# Altium schematic sheet Power_Selection.SchDoc
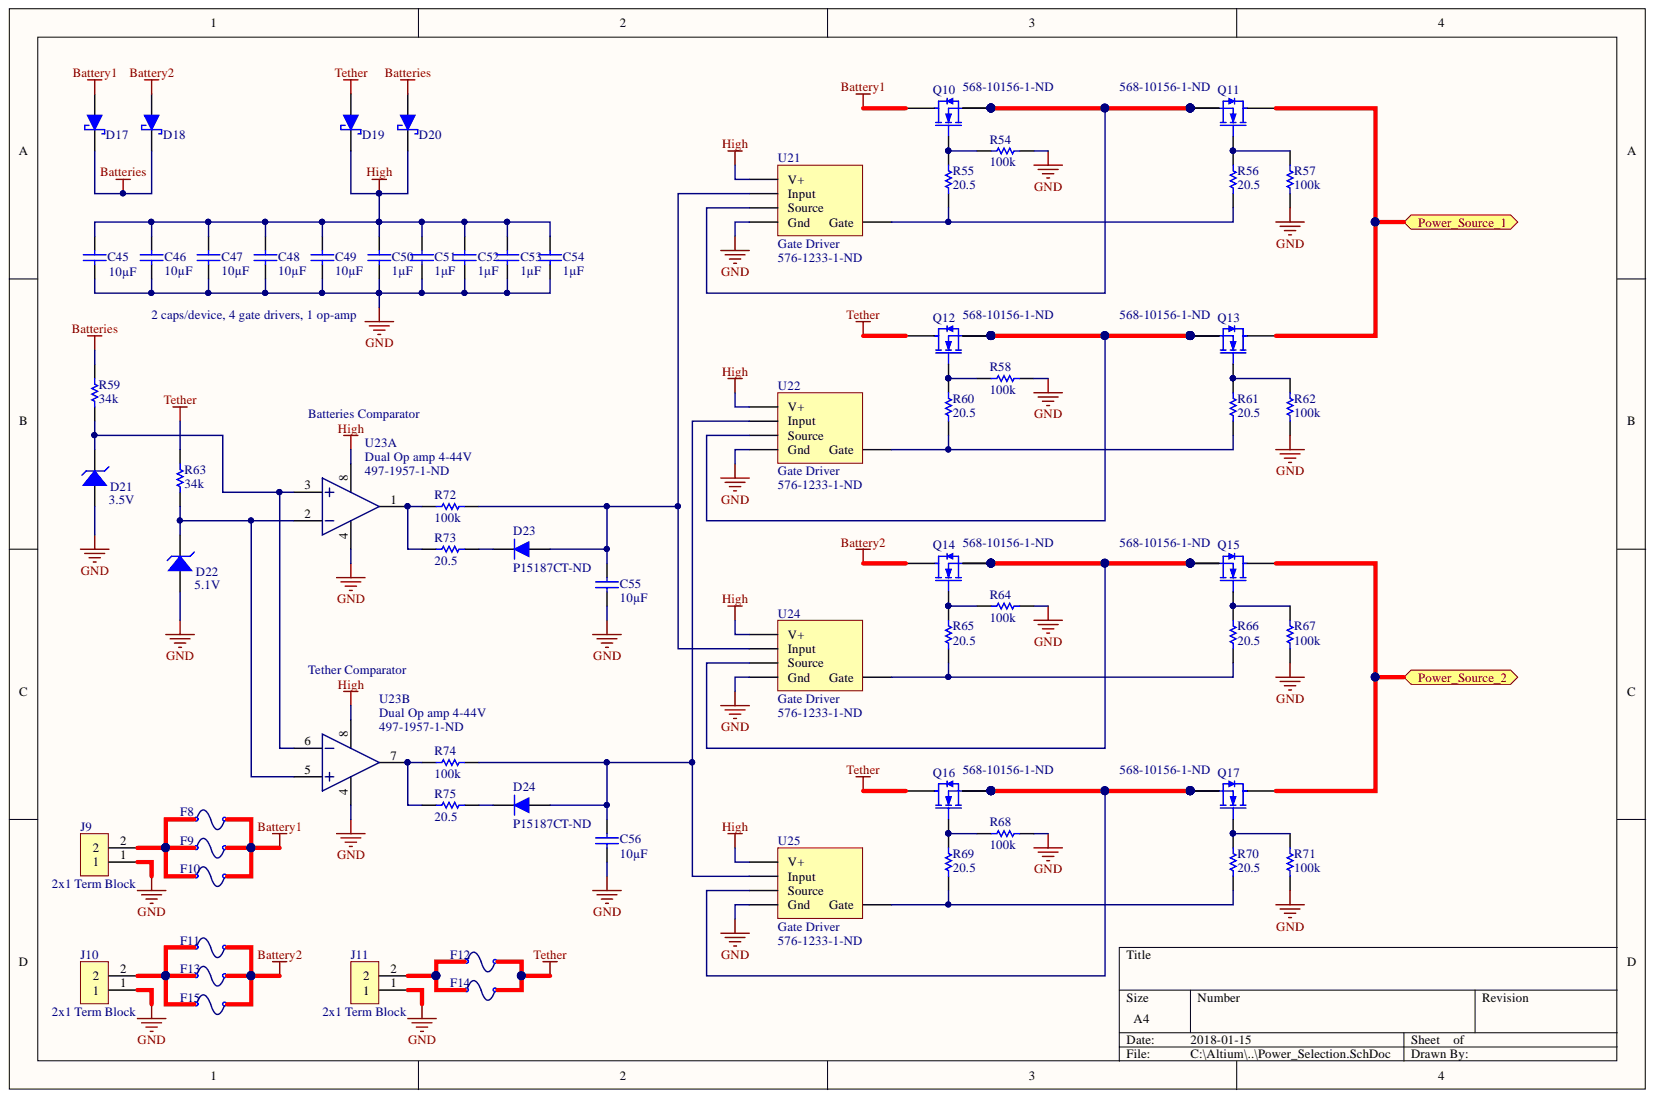
[diagram p0: Power_Selection.SchDoc]

## Parts
C45  10µF  [CAPC3216N(1206")]
C46  10µF  [CAPC3216N(1206")]
C47  10µF  [CAPC3216N(1206")]
C48  10µF  [CAPC3216N(1206")]
C49  10µF  [CAPC3216N(1206")]
C50  1μF  [CAPC1608N(0603")]
C51  1μF  [CAPC1608N(0603")]
C52  1μF  [CAPC1608N(0603")]
C53  1μF  [CAPC1608N(0603")]
C54  1μF  [CAPC1608N(0603")]
C55  10µF  [CAPC3216N(1206")]
C56  10µF  [CAPC3216N(1206")]
D17  CUS08F30H3FCT-ND  [SC-76]  (value not drawn)
D18  CUS08F30H3FCT-ND  [SC-76]  (value not drawn)
D19  CUS08F30H3FCT-ND  [SC-76]  (value not drawn)
D20  CUS08F30H3FCT-ND  [SC-76]  (value not drawn)
D21  Voltage - Breakdown (Min): 3.5V  [SODFL160X70-2N]  (hidden comment: UCLAMP3301H.TCT)
D22  Voltage - Zener (Nom) (Vz): 5.1V  (hidden comment: MM3Z5V1ST1G)
D23  Supplier Part Number 1: P15187CT-ND  [SODFL535X110-2N]  (hidden comment: DA2S10400L, SOD323F / SC-90)  (no BOM)
D24  Supplier Part Number 1: P15187CT-ND  [SODFL535X110-2N]  (hidden comment: DA2S10400L, SOD323F / SC-90)  (no BOM)
F8  MINI  [MINI BLADE FUSEHOLDER]  (value not drawn)
F9  MINI  [MINI BLADE FUSEHOLDER]  (value not drawn)
F10  MINI  [MINI BLADE FUSEHOLDER]  (value not drawn)
F11  MINI  [MINI BLADE FUSEHOLDER]  (value not drawn)
F12  MINI  [MINI BLADE FUSEHOLDER]  (value not drawn)
F13  MINI  [MINI BLADE FUSEHOLDER]  (value not drawn)
F14  MINI  [MINI BLADE FUSEHOLDER]  (value not drawn)
F15  MINI  [MINI BLADE FUSEHOLDER]  (value not drawn)
J9  2x1 Term Block  [2x1 Terminal Blocks EM2929]
J10  2x1 Term Block  [2x1 Terminal Blocks EM2929]
J11  2x1 Term Block  [2x1 Terminal Blocks EM2929]
Q10  Supplier Part Number 1: 568-10156-1-ND  [LFPAK-PowerSO8]  (hidden comment: MOSFET N-Channel, 40V, 100A, 272W)
Q11  Supplier Part Number 1: 568-10156-1-ND  [LFPAK-PowerSO8]  (hidden comment: MOSFET N-Channel, 40V, 100A, 272W)
Q12  Supplier Part Number 1: 568-10156-1-ND  [LFPAK-PowerSO8]  (hidden comment: MOSFET N-Channel, 40V, 100A, 272W)
Q13  Supplier Part Number 1: 568-10156-1-ND  [LFPAK-PowerSO8]  (hidden comment: MOSFET N-Channel, 40V, 100A, 272W)
Q14  Supplier Part Number 1: 568-10156-1-ND  [LFPAK-PowerSO8]  (hidden comment: MOSFET N-Channel, 40V, 100A, 272W)
Q15  Supplier Part Number 1: 568-10156-1-ND  [LFPAK-PowerSO8]  (hidden comment: MOSFET N-Channel, 40V, 100A, 272W)
Q16  Supplier Part Number 1: 568-10156-1-ND  [LFPAK-PowerSO8]  (hidden comment: MOSFET N-Channel, 40V, 100A, 272W)
Q17  Supplier Part Number 1: 568-10156-1-ND  [LFPAK-PowerSO8]  (hidden comment: MOSFET N-Channel, 40V, 100A, 272W)
R54  100k  [RESC2012N(0805")]
R55  20.5  [RESC1608N(0603")]
R56  20.5  [RESC1608N(0603")]
R57  100k  [RESC2012N(0805")]
R58  100k  [RESC2012N(0805")]
R59  34k  [RESC1608N(0603")]
R60  20.5  [RESC1608N(0603")]
R61  20.5  [RESC1608N(0603")]
R62  100k  [RESC2012N(0805")]
R63  34k  [RESC1608N(0603")]
R64  100k  [RESC2012N(0805")]
R65  20.5  [RESC1608N(0603")]
R66  20.5  [RESC1608N(0603")]
R67  100k  [RESC2012N(0805")]
R68  100k  [RESC2012N(0805")]
R69  20.5  [RESC1608N(0603")]
R70  20.5  [RESC1608N(0603")]
R71  100k  [RESC2012N(0805")]
R72  100k  [RESC2012N(0805")]
R73  20.5  [RESC1608N(0603")]
R74  100k  [RESC2012N(0805")]
R75  20.5  [RESC1608N(0603")]
U21  Gate Driver  Supplier Part Number 1: 576-1233-1-ND  [SOC 8]
U22  Gate Driver  Supplier Part Number 1: 576-1233-1-ND  [SOC 8]
U23  Dual Op amp 4-44V  Supplier 1 Part Number: 497-1957-1-ND  [SOC-8]  (parts A,B)
U24  Gate Driver  Supplier Part Number 1: 576-1233-1-ND  [SOC 8]
U25  Gate Driver  Supplier Part Number 1: 576-1233-1-ND  [SOC 8]

## Ports
Power_Source_1, Power_Source_2

## Nets
Batteries: D17.K D18.K D20.A R59.2
Battery1: D17.A F8.2 F9.2 F10.2 Q10.5
Battery2: D18.A F11.2 F13.2 F15.2 Q14.5
GND: C45.1 C46.1 C47.1 C48.1 C49.1 C50.1 C51.1 C52.1 C53.1 C54.1 C55.1 C56.1 D21.1 D22.1 J9.1 J10.1 J11.1 R54.1 R57.2 R58.1 R62.2 R64.1 R67.2 R68.1 R71.2 U21.4(Gnd) U22.4(Gnd) U23.4 U24.4(Gnd) U25.4(Gnd)
High: C45.2 C46.2 C47.2 C48.2 C49.2 C50.2 C51.2 C52.2 C53.2 C54.2 D19.K D20.K U21.1(V+) U22.1(V+) U23.8 U24.1(V+) U25.1(V+)
Tether: D19.A F12.2 F14.2 Q12.5 Q16.5 R63.2
Power_Source_1: Q11.5 Q13.5 ; port Power_Source_1
Power_Source_2: Q15.5 Q17.5 ; port Power_Source_2
NetC55_2: C55.2 D23.1 R72.2 U21.2(Input) U24.2(Input)
NetC56_2: C56.2 D24.1 R74.2 U22.2(Input) U25.2(Input)
NetD21_2: D21.2 R59.1 U23.3 U23.6
NetD22_2: D22.2 R63.1 U23.2 U23.5
NetD23_2: D23.2 R73.2
NetD24_2: D24.2 R75.2
NetF8_1: F8.1 F9.1 F10.1 J9.2
NetF11_1: F11.1 F13.1 F15.1 J10.2
NetF12_1: F12.1 F14.1 J11.2
NetQ10_1: Q10.1 Q10.2 Q10.3 Q11.1 Q11.2 Q11.3 U21.3(Source)
NetQ10_4: Q10.4 R54.2 R55.2
NetQ11_4: Q11.4 R56.2 R57.1
NetQ12_1: Q12.1 Q12.2 Q12.3 Q13.1 Q13.2 Q13.3 U22.3(Source)
NetQ12_4: Q12.4 R58.2 R60.2
NetQ13_4: Q13.4 R61.2 R62.1
NetQ14_1: Q14.1 Q14.2 Q14.3 Q15.1 Q15.2 Q15.3 U24.3(Source)
NetQ14_4: Q14.4 R64.2 R65.2
NetQ15_4: Q15.4 R66.2 R67.1
NetQ16_1: Q16.1 Q16.2 Q16.3 Q17.1 Q17.2 Q17.3 U25.3(Source)
NetQ16_4: Q16.4 R68.2 R69.2
NetQ17_4: Q17.4 R70.2 R71.1
NetR55_1: R55.1 R56.1 U21.5
NetR60_1: R60.1 R61.1 U22.5
NetR65_1: R65.1 R66.1 U24.5
NetR69_1: R69.1 R70.1 U25.5
NetR72_1: R72.1 R73.1 U23.1
NetR74_1: R74.1 R75.1 U23.7

## Library data of the parts
C45-C49 C55 C56 = 10uF 50V 1206 SMD, Ceramic: Capacitor, Ceramic, 50V, +/- 10%, 1206 SMD, X5R; Component Kind: Standard; PackageDescription: Chip Capacitor; Published: 14-06-01; Supplier 1: Digi-Key; Supplier Part Number 1: 1276-2876-1-ND; Value: 10µF; Library Name: AUVic_Integrated_Library_Capacitors.IntLib; Publisher: AUVic
C50-C54 = 1uF 50V 0603 SMD, Ceramic: Capacitor, Ceramic, 50V, +/- 10%, 0603 SMD, X5R; Component Kind: Standard; Date Created: 2017-10-26; PackageDescription: Chip Capacitor; Supplier 1: Digi-Key; Supplier Part Number 1: 1276-1860-6-ND; Value: 1μF; Created By: Lyden Smith; Library Name: AUVic_Integrated_Library_Capacitors.IntLib
D17-D20 = CUS08F30H3FCT-ND: Diode, Schottky, 30V, 800mA; Component Kind: Standard; Date Created: 2016-09-09; Supplier 1: Digi-Key; Supplier Part Number 1: CUS08F30H3FCT-ND; Created By: Andy Bates; Library Name: AUVic_Integrated_Library_Diodes.IntLib; Publisher: AUVic
D21 = UCLAMP3301H.TCT: Diode, TVS, 3.5V; Manufacturer: Semtech Corporation; Power - Peak Pulse: 40W; Type: Zener; Unidirectional Channels: 1; Voltage - Clamping (Max) @ Ipp: 8V; Voltage - Reverse Standoff (Typ): 3.3V; Component Kind: Standard; RoHS: RoHS Compliant; Supplier 1: Digi-Key; Supplier Part Number 1: UCLAMP3301HCT-ND; Library Name: AUVic_Integrated_Library_Diodes.IntLib
D22 = MM3Z5V1ST1G: DIODE ZENER 5.1V 200MW SOD323; Manufacturer: ON Semiconductor; Current - Reverse Leakage @ Vr: 2µA @ 2V; Impedance (Max) (Zzt): 60 Ohm; Tolerance: ±2%; Voltage - Forward (Vf) (Max) @ If: 900mV @ 10mA; Category: Discrete Semiconductor Products; Component Kind: Standard; ComponentLink1Description: http://www.onsemi.com/pub_link/Collateral/MM3Z2V4ST1-D.PDF; ComponentLink1URL: http://www.onsemi.com/pub_link/Collateral/MM3Z2V4ST1-D.PDF; Manufacturer Part Number: MM3Z5V1ST1G; Mounting Type: Surface Mount; Operating Temperature: -65°C ~ 150°C; Package / Case: SC-76, SOD-323; Packaging: Cut Tape (CT); Power - Max: 200mW; Pricing 1: 1=0.15, 10=0.137, 25=0.1248, 100=0.0899, 250=0.05296, 500=0.04394, 1000=0.02997 (USD); RoHS: RoHS Compliant; Stock 1: 60540; Supplier 1: Digi-Key; Supplier Device Package: SOD-323; Supplier Part Number 1: MM3Z5V1ST1GOSCT-ND; Library Name: AUVic_Integrated_Library_Diodes.IntLib
D23 D24 = DA2S10400L, SOD323F / SC-90: Forward Voltage Drop: 1.1V; Date Created: 2016-09-08; Description: DA2S10400L, SOD323F / SC-90; Supplier 1: Digi-Key; Created By: Andy Bates; Library Name: AUVic_Integrated_Library_Diodes.IntLib; Publisher: AUVic
F8-F15 = MINI, ATC, ATO, etc. Blade Fuse: Blade Fuse Holder, 30A; Component Kind: Standard; Date Created: 2018-01-11; Supplier 1: Digi-Key; Supplier Part Number 1: 36-3557-2-ND; Type: MINI; Created By: Lyden Smith; Library Name: AUVic_Integrated_Library_Fuses.IntLib
J9-J11 = 2x1 Terminal Block, 65A, 6-20AWG: Made by: Lyden Smith; Component Kind: Standard; Date Made: 2017-11-13; Supplier 1: Digi-Key; Supplier Part Number 1: 283-4339-ND; Library Name: AUVic_Integrated_Library_Connectors.IntLib
Q10-Q17 = MOSFET N-Channel, 40V, 100A, 272W: MOSFET N-Channel, 40V, 100A, 272W, Enh-Mode; Latest_Revision_By: Ashwin Sira; Latest_Revision_Note: Attached footprint; MFG: NXP Semiconductors; MFG#: PSMN1R8-40YLC,115; Component Kind: Standard; Latest_Revision_Date: 2016_04_26; Reviewed_Date: 2016_04_26; Supplier 1: Digi-Key; Created By: Ashwin Sira; Library Name: AUVic_Integrated_Library_Transistors.IntLib; Publisher: AUVic; Reviewed_By: Ashwin Sira
R54 R57 R58 R62 R64 R67 R68 R71 R72 R74 = 100kR, 1%, 1/4W, 0805 SMD: Made By: Ashwin Sira; Owned By: AUVic; Component Kind: Standard; Created On: 14-05-31; Description: 100kR, 1%, 1/4W, 0805 SMD; Last Edited: 14-05-31; Library Name: AUVic_Integrated_Library_Resistors.IntLib; Supplier 1: Digi-Key; Supplier Part Number 1: 311-1.00MCRCT-ND; Value: 100k
R55 R56 R60 R61 R65 R66 R69 R70 R73 R75 = 20.5 Ohm, 1%, 1/10W , 0603, SMD: Component Kind: Standard; Date Created: 2017-06-23; Description: 20.5 Ohm, 1%, 1/10W , 0603, SMD; Supplier 1: Digi-Key; Supplier Part Number 1: P20.5HCT-ND; Value: 20.5; Created By: Gabriel Green; Library Name: AUVic_Integrated_Library_Resistors.IntLib; Publisher: AUVic
R59 R63 = 34kR, 1%, 1/10W, 0603: RES 34K OHM 1/10W 1% 0603 SMD; Manufacturer: Yageo; Manufacturer Part Number: RC0603FR-0734KL; Power (Watts): 0.1W, 1/10W; Temperature Coefficient: ±100ppm/°C; Category: Resistors; Component Kind: Standard; ComponentLink1Description: http://www.yageo.com/documents/recent/PYu-RC0603_51_RoHS_L_4.pdf; ComponentLink1URL: http://www.yageo.com/documents/recent/PYu-RC0603_51_RoHS_L_4.pdf; Composition: Thick Film; Features: Moisture Resistant; Height: 0.022" (0.55mm); Number of Terminations: 2; Operating Temperature: -55°C ~ 155°C; Package / Case: 0603 (1608 Metric); Packaging: Cut Tape (CT); Pricing 1: 1=0.1, 10=0.015, 25=0.0108, 100=0.006, 250=0.00456, 500=0.00364, 1000=0.00268, 2500=0.00233 (USD); Resistance: 34k; RoHS: RoHS Compliant; Size / Dimension: 0.063" L x 0.031" W (1.60mm x 0.80mm); Stock 1: 192; Supplier 1: Digi-Key; Supplier Device Package: 0603; Supplier Part Number 1: 311-34.0KHRCT-ND; Tolerance: ±1%; Library Name: AUVic_Integrated_Library_Resistors.IntLib
U21 U22 U24 U25 = Gate Driver TTL Logic 3 - 30V: Component Kind: Standard; Supplier 1: Digi-Key; Library Name: AUVic_Integrated_Library_Gate_Drivers.IntLib
U23 = Dual Op amp 4-44V: MC33172; Made by: Andy Bates; Component Kind: Standard; Created on: 06/10/2016; Supplier 1: Digikey; Supplier 2: Digi-Key; Supplier Part Number 2: 497-1957-1-ND; Library Name: AUVic_Integrated_Library_Op_amps.IntLib
